# Altium schematic sheet S04_Power_VREG_RevF.SchDoc
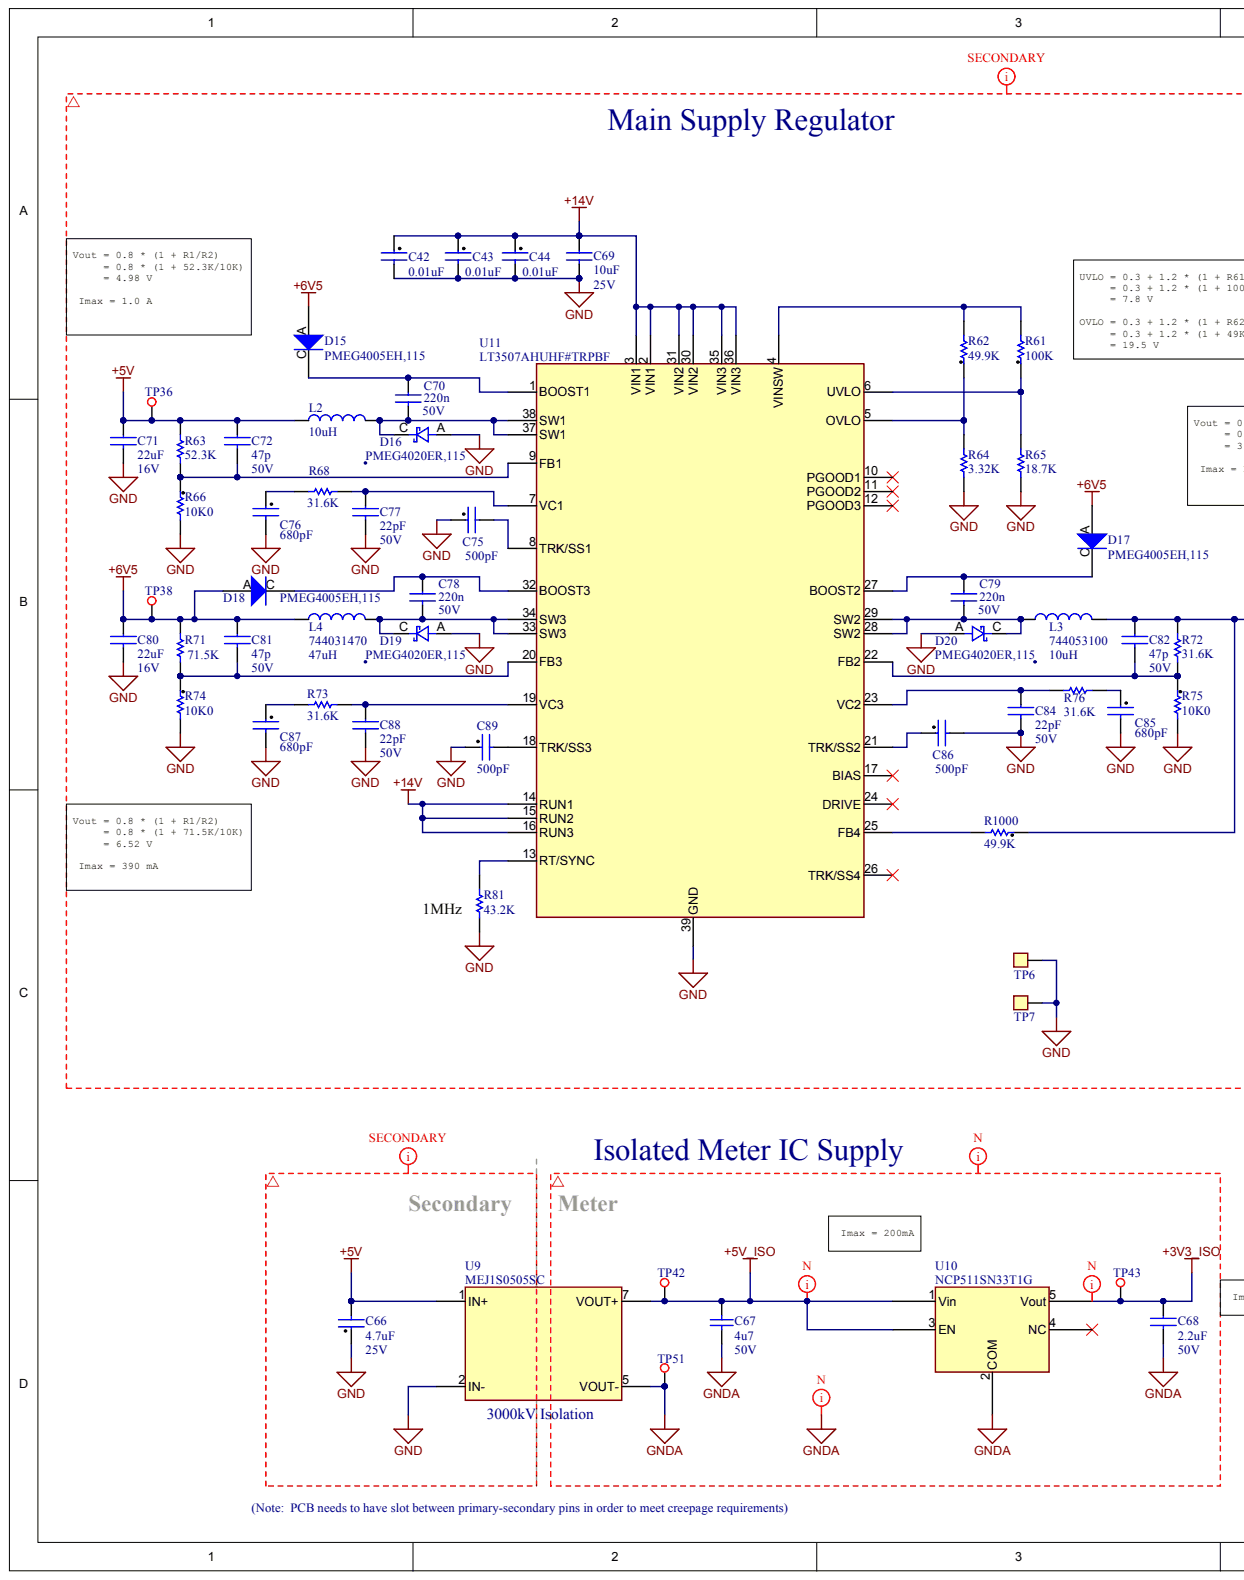
[diagram p0: S04_Power_VREG_RevF.SchDoc, left]
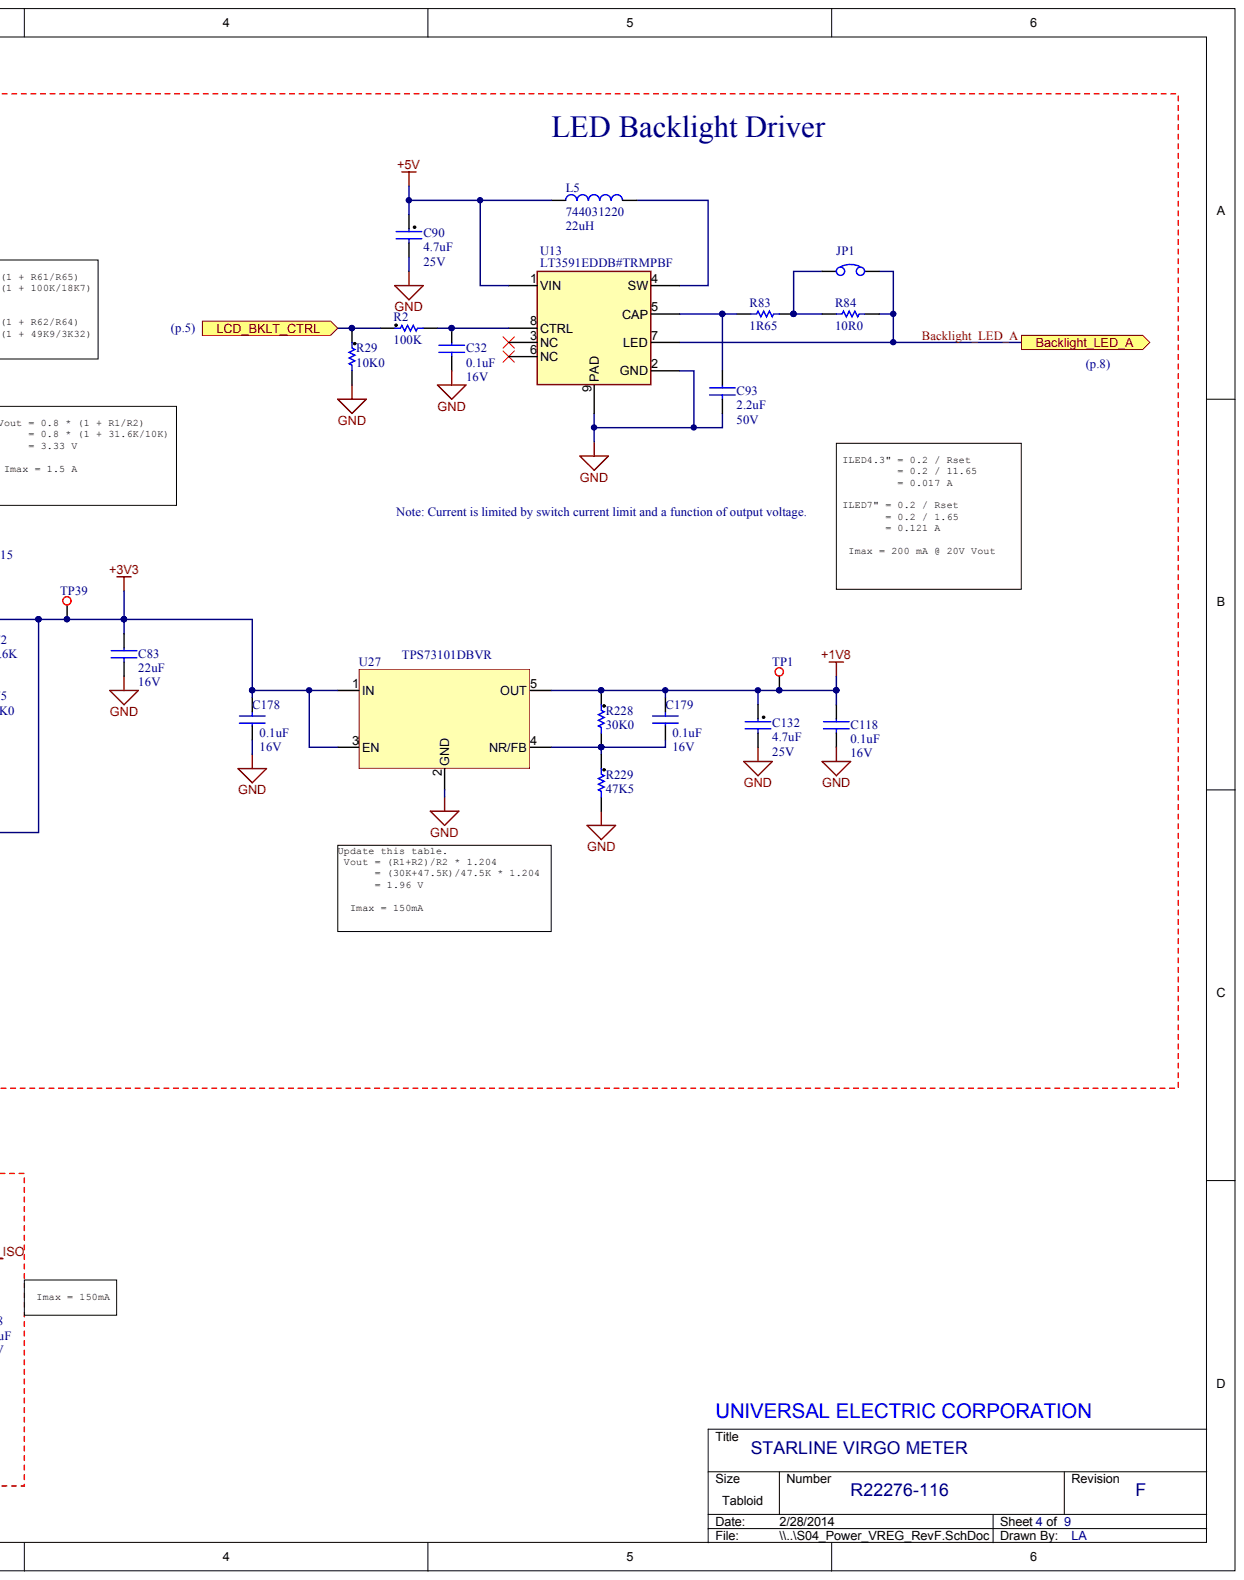
[diagram p1: S04_Power_VREG_RevF.SchDoc, right]

## Parts
C32  value 0.1uF  Voltage: 16V  (hidden comment: CAP CER 0.1uF X5R 10% 16V SM_0402)
C42  0.01uF
C43  0.01uF
C44  0.01uF
C66  Voltage: 25V  (hidden comment: 4.7uF)
C67  value 4u7  VOLTAGE: 50V  (hidden comment: NUV_Cap)
C68  Voltage: 50V  (hidden comment: 2.2uF)
C69  Voltage: 25V  (hidden comment: 10uF)
C70  VOLTAGE: 50V  (hidden comment: 220n)
C71  value 22uF  Voltage: 16V  (hidden comment: CAP CER 22uF 10% 16V SM_0805)
C72  VOLTAGE: 50V  (hidden comment: 47p)
C75  500pF
C76  680pF
C77  Voltage: 50V  (hidden comment: 22pF)
C78  VOLTAGE: 50V  (hidden comment: 220n)
C79  VOLTAGE: 50V  (hidden comment: 220n)
C80  value 22uF  Voltage: 16V  (hidden comment: CAP CER 22uF 10% 16V SM_0805)
C81  VOLTAGE: 50V  (hidden comment: 47p)
C82  VOLTAGE: 50V  (hidden comment: 47p)
C83  value 22uF  Voltage: 16V  (hidden comment: CAP CER 22uF 10% 16V SM_0805)
C84  Voltage: 50V  (hidden comment: 22pF)
C85  680pF
C86  500pF
C87  680pF
C88  Voltage: 50V  (hidden comment: 22pF)
C89  500pF
C90  Voltage: 25V  (hidden comment: 4.7uF)
C93  Voltage: 50V  (hidden comment: 2.2uF)
C118  value 0.1uF  Voltage: 16V  (hidden comment: CAP CER 0.1uF X5R 10% 16V SM_0402)
C132  Voltage: 25V  (hidden comment: 4.7uF)
C178  value 0.1uF  Voltage: 16V  (hidden comment: CAP CER 0.1uF X5R 10% 16V SM_0402)
C179  value 0.1uF  Voltage: 16V  (hidden comment: CAP CER 0.1uF X5R 10% 16V SM_0402)
D15  PMEG4005EH,115
D16  PMEG4020ER,115
D17  PMEG4005EH,115
D18  PMEG4005EH,115
D19  PMEG4020ER,115
D20  PMEG4020ER,115
JP1  TMM-102-01-G-S-SM  (value not drawn)
L2  10uH
L3  MFG_PART_NO: 744053100  (hidden comment: 10uH)
L4  MFG_PART_NO: 744031470  (hidden comment: 47uH)
L5  MFG_PART_NO: 744031220  (hidden comment: 22uH)
R2  value 100K  (hidden comment: RES 100K 1/16W 1% SM_0402)
R29  10K0
R61  value 100K  (hidden comment: RES 100K 1/16W 1% SM_0402)
R62  value 49.9K  (hidden comment: RES 49.9K 1/16W 1% 100PPM SM_0402)
R63  value 52.3K  (hidden comment: RES 52.3K 1/16W 1% SM_0402)
R64  value 3.32K  (hidden comment: RES 3.32K 1/16W 1% SM_0402)
R65  value 18.7K  (hidden comment: RES 18.7K 1/16W 1% SM_0402)
R66  10K0
R68  value 31.6K  (hidden comment: RES 31.6K 1/16W 1% SM_0402)
R71  value 71.5K  (hidden comment: RES 71.5K 1/16W 1% SM_0402)
R72  value 31.6K  (hidden comment: RES 31.6K 1/16W 1% SM_0402)
R73  value 31.6K  (hidden comment: RES 31.6K 1/16W 1% SM_0402)
R74  10K0
R75  10K0
R76  value 31.6K  (hidden comment: RES 31.6K 1/16W 1% SM_0402)
R81  value 43.2K  (hidden comment: RES 43.2K 1/16W 1% SM_0402)
R83  1R65
R84  value 10R0  (hidden comment: RES 10R0 1/10W 1% SM_0603)
R228  30K0
R229  47K5
R1000  value 49.9K  (hidden comment: RES 49.9K 1/16W 1% 100PPM SM_0402)
TP1  =VALUE
TP6  TP_5016  (value not drawn)
TP7  TP_5016  (value not drawn)
TP36  =VALUE
TP38  =VALUE
TP39  =VALUE
TP42  =VALUE
TP43  =VALUE
TP51  =VALUE
U9  MEJ1S0505SC
U10  NCP511SN33T1G
U11  LT3507AHUHF#TRPBF
U13  LT3591EDDB#TRMPBF
U27  TPS73101DBVR

## Ports
BACKLIGHT_LED_A, LCD_BKLT_CTRL

## Nets
+1V8: C118.1 C132.1 C179.2 R228.1 TP1.1 U27.5(OUT)
+3V3: C82.1 C83.1 C178.2 L3.1 R72.2 R1000.1 TP39.1 U27.1(IN) U27.3(EN)
+3V3_ISO: C68.1 TP43.1 U10.5(Vout)
+5V: C66.2 C71.1 C72.1 C90.1 L2.2 L5.2 R63.2 TP36.1 U9.1(IN+) U13.1(VIN)
+5V_ISO: C67.2 TP42.1 U9.7(VOUT+) U10.1(Vin) U10.3(EN)
+6V5: C80.1 C81.1 D15.A D17.A D18.A L4.2 R71.2 TP38.1
+14V: C42.1 C43.1 C44.1 C69.1 U11.2(VIN1) U11.3(VIN1) U11.14(RUN1) U11.15(RUN2) U11.16(RUN3) U11.30(VIN2) U11.31(VIN2) U11.35(VIN3) U11.36(VIN3)
GND: C32.2 C42.2 C43.2 C44.2 C66.1 C69.2 C71.2 C75.1 C76.2 C77.2 C80.2 C83.2 C84.2 C85.2 C86.2 C87.2 C88.2 C89.1 C90.2 C93.2 C118.2 C132.2 C178.1 D16.A D19.A D20.A R29.2 R64.1 R65.1 R66.2 R74.2 R75.2 R81.1 R229.2 TP6.1 TP7.1 U9.2(IN-) U11.39(GND) U13.2(GND) U13.9(PAD) U27.2(GND)
GNDA: C67.1 C68.2 TP51.1 U9.5(VOUT-) U10.2(COM)
BACKLIGHT_LED_A: JP1.2 R84.2 U13.7(LED) ; port BACKLIGHT_LED_A
LCD_BKLT_CTRL: R2.1 R29.1 ; port LCD_BKLT_CTRL
NetC32_1: C32.1 R2.2 U13.8(CTRL)
NetC70_1: C70.1 D15.C U11.1(BOOST1)
NetC70_2: C70.2 D16.C L2.1 U11.37(SW1) U11.38(SW1)
NetC72_2: C72.2 R63.1 R66.1 U11.9(FB1)
NetC75_2: C75.2 U11.8(TRK/SS1)
NetC76_1: C76.1 R68.1
NetC77_1: C77.1 R68.2 U11.7(VC1)
NetC78_1: C78.1 D18.C U11.32(BOOST3)
NetC78_2: C78.2 D19.C L4.1 U11.33(SW3) U11.34(SW3)
NetC79_1: C79.1 D17.C U11.27(BOOST2)
NetC79_2: C79.2 D20.C L3.2 U11.28(SW2) U11.29(SW2)
NetC81_2: C81.2 R71.1 R74.1 U11.20(FB3)
NetC82_2: C82.2 R72.1 R75.1 U11.22(FB2)
NetC84_1: C84.1 R76.1 U11.23(VC2)
NetC85_1: C85.1 R76.2
NetC86_1: C86.1 U11.21(TRK/SS2)
NetC87_1: C87.1 R73.1
NetC88_1: C88.1 R73.2 U11.19(VC3)
NetC89_2: C89.2 U11.18(TRK/SS3)
NetC93_1: C93.1 R83.1 U13.5(CAP)
NetC179_1: C179.1 R228.2 R229.1 U27.4(NR/FB)
NetJP1_1: JP1.1 R83.2 R84.1
NetL5_1: L5.1 U13.4(SW)
NetR61_1: R61.1 R65.2 U11.6(UVLO)
NetR61_2: R61.2 R62.2 U11.4(VINSW)
NetR62_1: R62.1 R64.2 U11.5(OVLO)
NetR81_2: R81.2 U11.13(RT/SYNC)
NetR1000_2: R1000.2 U11.25(FB4)
N00064:
N00065:
N00066:

Not connected: U10 4(NC); U11 10(PGOOD1) 11(PGOOD2) 12(PGOOD3) 17(BIAS) 24(DRIVE) 26(TRK/SS4); U13 3(NC) 6(NC)

## Library data of the parts
C32 C118 C178 C179 = (no symbol name): MFG_PART_NO: GRM155R71C104KA88D; MFG: Murata
C42-C44 = (no symbol name): Manufacturer P/N: GRM155R71E103KA01D; Manufacturer: Murata; Voltage-Rated: 25V; Tolerance: 0.1; Package: SM_0402; Capacitance: 0.01uF
C66 C90 C132 = (no symbol name): MFG_PART_NO: GRM21BR61E475KA12L; MFG: Murata; Value: 4.7uF
C67 = (no symbol name): MFG_PART_NO: 12105C475KAT2A; SYM_LVL: LEVEL2; LEVEL: Level 1; Commodity Code: ECA; MFG: AVX; TYPE: CER; TOLERANCE: 10%; DIELECTRIC: X7R; NUV_DESCRIPTION: Cap, CER, 4u7, X7R, 10%, 50V, SM_1210; COST_1K: 0.702; ALT_SYM: Yes; ORC_SYM: No; VARIATION: 0; Comments: Updated Dielectric; RESERVED1: J.Schriel; ROOM: *; NOSTUFF: *; LAYOUT_FOOTPRINT: 12105C475KAT2A; PACKAGE: SM_1210; ROHS: RoHS; DXD_SYM: No; ALT_FOOT: No; ALEG_FOOT: No; EXP_FOOT: No; REVISION: A; LAST_UPDATE: 4/23/2009; ComponentLink1URL: Datasheets/cx7r.pdf; ComponentLink1Description: Datasheet; ComponentLink2URL: http://www.avx.com/docs/Catalogs/cx7r.pdf; ComponentLink2Description: Datasheet (web)
C68 C93 = (no symbol name): MFG_PART_NO: C2012X5R1H225K; MFG: TDK; Value: 2.2uF
C69 = (no symbol name): MFG_PART_NO: C3216X7R1E106K; MFG: TDK; Value: 10uF
C70 C78 C79 = (no symbol name): MFG_PART_NO: C1608X7R1H224K; LEVEL: Level_1; PCBLIB: UEC01.PcbLib; MFG: TDK; TYPE: Capacitor; TOLERANCE: +/- 10%; NUV_DESCRIPTION: CAP CER 220NF 50V 10% X7R 0603; NOSTUFF: *; ROOM: *; VALUE: 220n; PACKAGE: SMT; ROHS: RoHS; Created By: N.Wennyk
C71 C80 C83 = (no symbol name): MFG_PART_NO: C2012X5R1C226K; MFG: TDK
C72 C81 C82 = (no symbol name): MFG_PART_NO: CC0402JRNPO9BN470; LEVEL: Level_1; PCBLIB: UEC01.PcbLib; MFG: Yageo; TYPE: Capacitor; TOLERANCE: +/- 5%; NUV_DESCRIPTION: CAP CER 47PF 50V 5% NPO 0402; NOSTUFF: *; ROOM: *; VALUE: 47p; PACKAGE: SMT; ROHS: RoHS; Created By: N.Wennyk
C75 C86 C89 = (no symbol name): Manufacturer P/N: 08055A501JAT2A; Manufacturer: AVX; Voltage-Rated: 50V; Tolerance: 0.05; Package: SM_0805; Capacitance: 500pF
C76 C85 C87 = (no symbol name): Manufacturer P/N: C1005C0G1E681J; Manufacturer: TDK; Voltage-Rated: 25V; Tolerance: 0.05; Package: SM_0402; Capacitance: 680pF
C77 C84 C88 = (no symbol name): MFG_PART_NO: CC0402JRNPO9BN220; MFG: Yageo; Value: 22pF
D15 D17 D18 = (no symbol name): LEVEL: Level_1; MFG: NXP; TYPE: Diode; VOLTAGE: 40V; NUV_DESCRIPTION: SCHOTTKY RECT 40V 0.5A SOD123F; MFG_PART_NO: PMEG4005EH,115; VALUE: PMEG4005EH,115; PACKAGE: SMT; ROHS: RoHS; Created By: K Desrochers
D16 D19 D20 = (no symbol name): LEVEL: Level_1; PCBLIB: UEC01.PcbLib; MFG: NXP Semiconductor; TYPE: Diode; VOLTAGE: 40V; NUV_DESCRIPTION: DIODE SCHOTTY 40V 2A SOD123W; MFG_PART_NO: PMEG4020ER,115; VALUE: PMEG4020ER,115; PACKAGE: SMT; ROHS: RoHS; Created By: N.Wennyk
JP1 = (no symbol name): LEVEL: Level_1; PCBLIB: UEC01.PcbLib; MFG: Samtec; NUV_DESCRIPTION: CONN HEADER 2MM SINGLE SMD 2POS; NOSTUFF: *; MFG_PART_NO: TMM-102-01-G-S-SM; TYPE: Connector; VALUE: TMM-102-01-G-S-SM; PACKAGE: SMT; ROHS: RoHS; Created By: N.Wennyk
L2 = (no symbol name): MFG_PART_NO: 74408943100; CURRENT: 1.65A; MFG: Wurth; TYPE: Inductor; VALUE: 10uH
L3 = (no symbol name): LEVEL: Level_1; PCBLIB: UEC01.PcbLib; MFG: Wurth; TYPE: Inductor; NUV_DESCRIPTION: INDUCTOR POWER 10UH 1.5A SMD; NOSTUFF: *; VALUE: 10uH; PACKAGE: SMT; ROHS: RoHS; Created By: N.Wennyk
L4 = (no symbol name): LEVEL: Level_1; PCBLIB: UEC01.PcbLib; MFG: Wurth; TYPE: Inductor; NUV_DESCRIPTION: INDUCTOR 47uH 390mA SMD; NOSTUFF: *; VALUE: 47uH; PACKAGE: SMT; ROHS: RoHS; Created By: N.Wennyk
L5 = (no symbol name): LEVEL: Level_1; MFG: Wurth; TYPE: Inductor; TOLERANCE: 30%; NUV_DESCRIPTION: INDUCTOR POWER 22UH .51A SMD; NOSTUFF: *; VALUE: 22uH; PACKAGE: SMT; ROHS: RoHS; LAST_UPDATE: 6/26/2012; Created By: K Desrochers
R2 R61 = (no symbol name): MFG_PART_NO: CRCW0402100KFKED; MFG: Vishay
R29 R66 R74 R75 = (no symbol name): Part Number: CRCW040210K0FKED; Manufacturer: Vishay; Power: 1/16W; Tolerance: 0.01; RESISTANCE: 10K0; Manufacturer P/N: CRCW040210K0FKED; Package: SMT; Type: Resistor
R62 R1000 = (no symbol name): MFG_PART_NO: CRCW040249K9FKED; MFG: Vishay
R63 = (no symbol name): MFG_PART_NO: CRCW040252K3FKED; MFG: Vishay
R64 = (no symbol name): MFG_PART_NO: CRCW04023K32FKED; MFG: Vishay
R65 = (no symbol name): MFG_PART_NO: CRCW040218K7FKED; MFG: Vishay
R68 R72 R73 R76 = (no symbol name): MFG_PART_NO: CRCW040231K6FKED; MFG: Vishay
R71 = (no symbol name): MFG_PART_NO: CRCW040271K5FKED; MFG: Vishay
R81 = (no symbol name): MFG_PART_NO: CRCW040243K2FKED; MFG: Vishay
R83 = (no symbol name): MFG_PART_NO: CRCW06031R65FKEA; SYM_LVL: LEVEL1; LEVEL: Level_1; MFG: Vishay; TOLERANCE: +/- 1%; NUV_DESCRIPTION: RES 1.65 OHM 1/10W 1% 0603 SMD; ROOM: *; NOSTUFF: *; VOLTAGE: =VOLTAGE; VALUE: 1R65; TYPE: Resistor; PACKAGE: 0603; ROHS: RoHS; LAST_UPDATE: 7/10/2012; Created By: K Desrochers
R84 = (no symbol name): MFG_PART_NO: CRCW060310R0FKEA; MFG: Vishay
R228 = (no symbol name): Part Number: CRCW040230K0FKED; Manufacturer: Vishay; Power: 1/16W; Tolerance: 0.01; RESISTANCE: 30K0; Manufacturer P/N: CRCW040230K0FKED; Package: SMT; Type: Resistor
R229 = (no symbol name): Part Number: CRCW040247K5FKED; Manufacturer: Vishay; Power: 1/16W; Tolerance: 0.01; RESISTANCE: 47K5; Manufacturer P/N: CRCW040247K5FKED; Package: SMT; Type: Resistor
TP1 TP36 TP38 TP39 TP42 TP43 TP51 = (no symbol name): SYM_LVL: LEVEL1; LEVEL: Level_1; NUV_DESCRIPTION: Test Point; ROOM: *; NOSTUFF: NOSTUFF; TYPE: Test Point; PACKAGE: SMT; ROHS: RoHS; LAST_UPDATE: 6/21/2012; Created By: K Desrochers
TP6 TP7 = (no symbol name): MFG_PART_NO: 5016; SYM_LVL: LEVEL1; LEVEL: Level_1; PCBLIB: UEC01.PcbLib; MFG: Keystone; NUV_DESCRIPTION: Testpoint, Compact Loop, SMT; ROOM: *; NOSTUFF: *; TYPE: Testpoint; VALUE: TP_5016; PACKAGE: SMT; ROHS: RoHS; LAST_UPDATE: 7/17/2012; Created By: J Valentin
U9 = (no symbol name): PCBLIB: UEC01.PcbLib; MFG: Murata; NUV_DESCRIPTION: CONVERTER DC/DC 1W 5V 200MA; MFG_PART_NO: MEJ1S0505SC; TYPE: IC; VALUE: MEJ1S0505SC; PACKAGE: Thru-Hole; ROHS: RoHS
U10 = (no symbol name): LEVEL: Level_1; MFG: ON Semi; NUV_DESCRIPTION: IC REG LDO 3.3V .15A 5TSOP; NOSTUFF: *; MFG_PART_NO: NCP511SN33T1G; TYPE: IC; VALUE: NCP511SN33T1G; PACKAGE: SMT; ROHS: RoHS; LAST_UPDATE: 8/14/2012; Created By: K Desrochers
U11 = (no symbol name): LEVEL: Level_1; PCBLIB: UEC01.PcbLib; MFG: Linear Technology; NUV_DESCRIPTION: IC REG QD BUCK/LINEAR 38QFN; MFG_PART_NO: LT3507AHUHF#TRPBF; TYPE: IC; VALUE: LT3507AHUHF#TRPBF; PACKAGE: SMT; ROHS: RoHS; Created By: N.Wennyk
U13 = (no symbol name): LEVEL: Level_1; PCBLIB: UEC01.PcbLib; MFG: Linear Technology; NUV_DESCRIPTION: LED DRIVER WHITE BCKLGT 8-DFN; MFG_PART_NO: LT3591EDDB#TRMPBF; TYPE: IC; VALUE: LT3591EDDB#TRMPBF; PACKAGE: SMT; ROHS: RoHS; LAST_UPDATE: 6/26/2012; Created By: K Desrochers
U27 = (no symbol name): Manufacturer: TI; Current Rating: 0.150A; Part Number: TPS73101DBVR; Manufacturer P/N: TPS73101DBVR; Package: SOT23-5
